# Altium schematic sheet Sensors.SchDoc(Sensors)
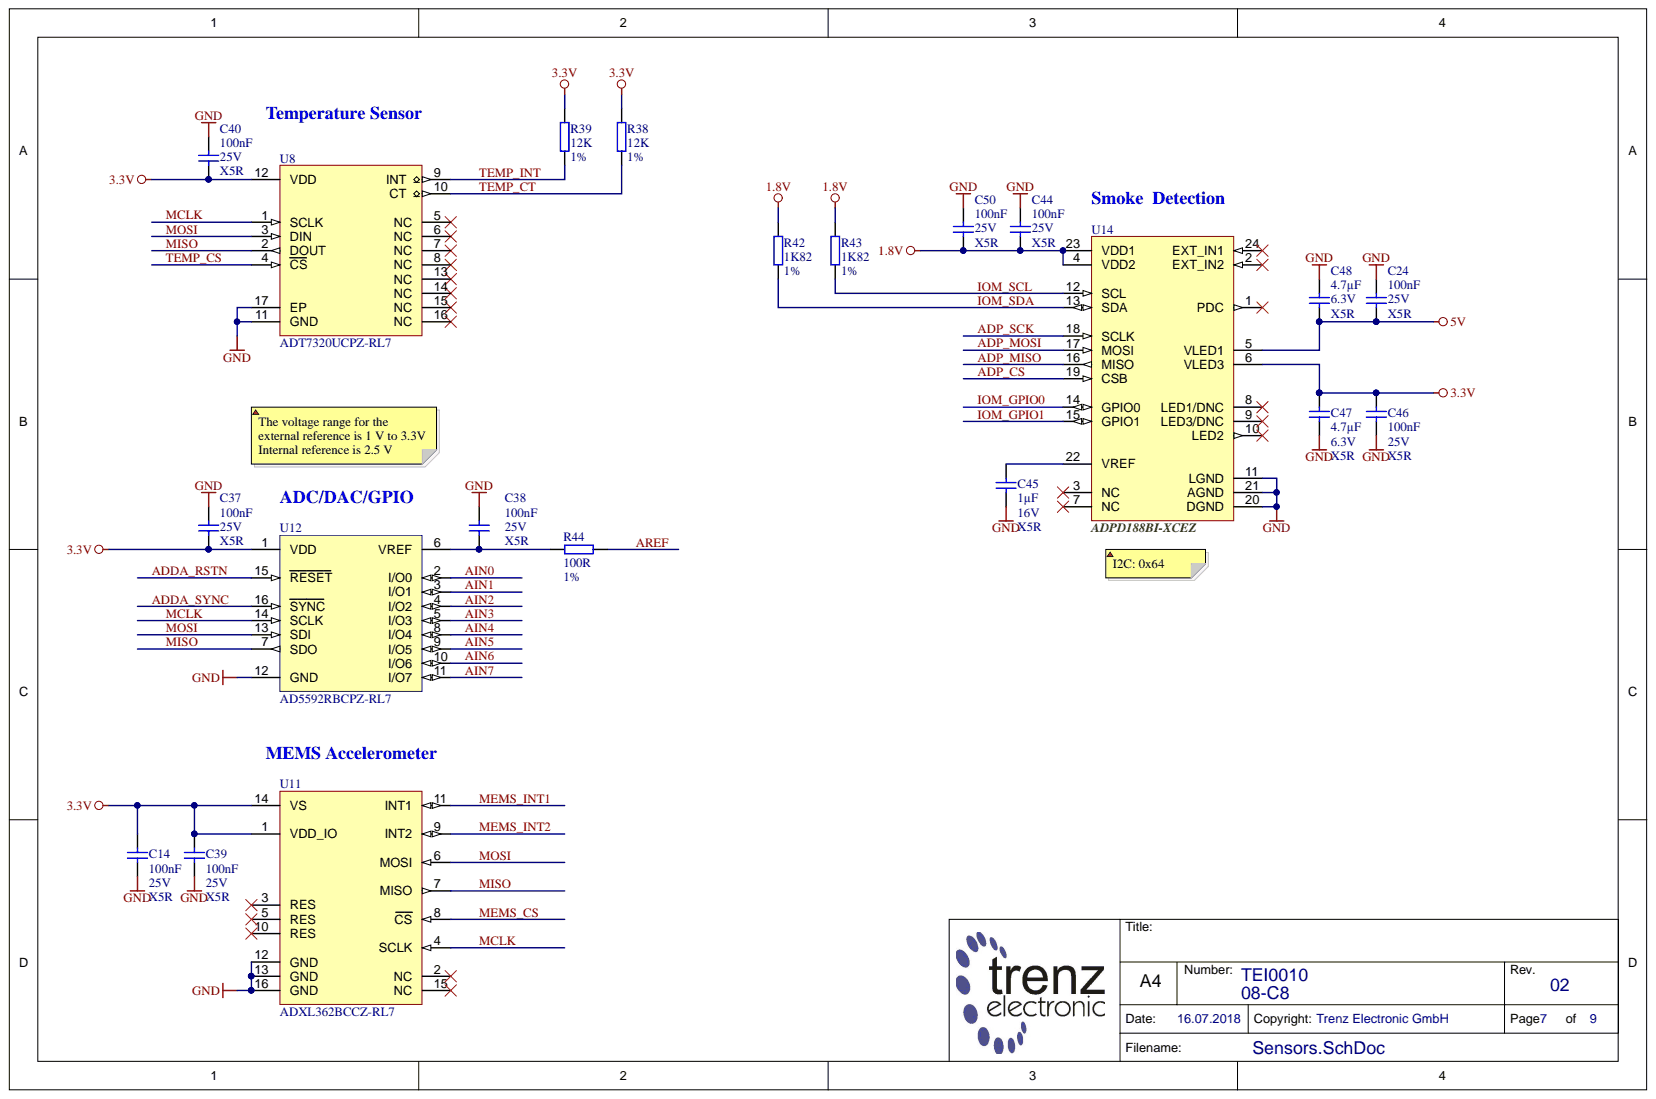
[diagram p0: Sensors.SchDoc]

## Parts
C14  100nF  Technology: X5R  Voltage: 25V  [CAPC1005X55N]
C24  100nF  Technology: X5R  Voltage: 25V  [CAPC1005X55N]
C37  100nF  Technology: X5R  Voltage: 25V  [CAPC1005X55N]
C38  100nF  Technology: X5R  Voltage: 25V  [CAPC1005X55N]
C39  100nF  Technology: X5R  Voltage: 25V  [CAPC1005X55N]
C40  100nF  Technology: X5R  Voltage: 25V  [CAPC1005X55N]
C44  100nF  Technology: X5R  Voltage: 25V  [CAPC1005X55N]
C45  1µF  Technology: X5R  Voltage: 16V  [CAPC1005X55N]
C46  100nF  Technology: X5R  Voltage: 25V  [CAPC1005X55N]
C47  4.7µF  Technology: X5R  Voltage: 6.3V  [CAPC1005X60N]
C48  4.7µF  Technology: X5R  Voltage: 6.3V  [CAPC1005X60N]
C50  100nF  Technology: X5R  Voltage: 25V  [CAPC1005X55N]
R38  12K  Tolerance: 1%  [RESC1005X40N]
R39  12K  Tolerance: 1%  [RESC1005X40N]
R42  1K82  Tolerance: 1%  [RESC1005X40N]
R43  1K82  Tolerance: 1%  [RESC1005X40N]
R44  100R  Tolerance: 1%  [RESC1005X40N]
U8  ADT7320UCPZ-RL7  [QFN65P400X400X80-17N]
U11  ADXL362BCCZ-RL7  [PQFN50P300X322X114-16N]
U12  AD5592RBCPZ-RL7  [QFN50P300X300X80-16N]
U14  ADPD188BI-XCEZ  [PQFN50P500X380X100-24N]

## Nets
1.8V: C44.1 C50.1 R42.2 R43.2 U14.4 U14.23
3.3V: C14.1 C37.1 C39.1 C40.1 C46.2 C47.1 R38.2 R39.2 U8.12(VDD) U11.1(VDD_IO) U11.14(VS) U12.1(VDD) U14.6
5V: C24.1 C48.1 U14.5
GND: C14.2 C24.2 C37.2 C38.2 C39.2 C40.2 C44.2 C45.2 C46.1 C47.2 C48.2 C50.2 U8.11(GND) U8.17(EP) U11.12(GND) U11.13(GND) U11.16(GND) U12.12(GND) U14.11 U14.20 U14.21
ADDA_RSTN: U12.15(RESET)
ADDA_SYNC: U12.16(SYNC)
ADP_CS: U14.19
ADP_MISO: U14.16
ADP_MOSI: U14.17
ADP_SCK: U14.18
AIN0: U12.2(I/O0)
AIN1: U12.3(I/O1)
AIN2: U12.4(I/O2)
AIN3: U12.5(I/O3)
AIN4: U12.8(I/O4)
AIN5: U12.9(I/O5)
AIN6: U12.10(I/O6)
AIN7: U12.11(I/O7)
AREF: R44.2
IOM_GPIO0: U14.14
IOM_GPIO1: U14.15
IOM_SCL: R43.1 U14.12
IOM_SDA: R42.1 U14.13
MCLK: U8.1(SCLK) U11.4(SCLK) U12.14(SCLK)
MEMS_CS: U11.8(CS)
MEMS_INT1: U11.11(INT1)
MEMS_INT2: U11.9(INT2)
MISO: U8.2(DOUT) U11.7(MISO) U12.7(SDO)
MOSI: U8.3(DIN) U11.6(MOSI) U12.13(SDI)
TEMP_CS: U8.4(CS)
TEMP_CT: R38.1 U8.10(CT)
TEMP_INT: R39.1 U8.9(INT)
NetC38_1: C38.1 R44.1 U12.6(VREF)
NetC45_1: C45.1 U14.22

Not connected: U8 5(NC) 6(NC) 7(NC) 8(NC) 13(NC) 14(NC) 15(NC) 16(NC); U11 2(NC) 3(RES) 5(RES) 10(RES) 15(NC); U14 1 2 3 7 8 9 10 24

## Library data of the parts
C14 C24 C37-C40 C44 C46 C50 = 22472: KON 100nF 25V X5R ±10% SMD BF 1005 (0402); Database Table Name: C_1005_0402; Mixed: SMT; Preferred: Yes; Temperature-Range: -55/+85; Value: 100n; AN: 22472; Case: 1005 (0402); Component Kind: Standard; Library Name: TE.DbLib
C45 = 26579: KON 1µF 16V X5R 10% SMD BF 1005 (0402); Database Table Name: C_1005_0402; Mixed: SMT; Temperature-Range: -55/+85; Value: 1u; AN: 26579; Case: 1005 (0402); Component Kind: Standard; Library Name: TE.DbLib; Tolerance: 10%
C47 C48 = 24719: KON 4.7µF 6.3V X5R 20% SMD BF 1005 (0402); Database Table Name: C_1005_0402; Mixed: SMT; Preferred: Yes; Temperature-Range: -55/+85; Value: 4.7u; AN: 24719; Case: 1005 (0402); Component Kind: Standard; Library Name: TE.DbLib; Tolerance: 20%
R38 R39 = 22598: WID SMD 12K 1% 0,063W  BF 1005 (0402); Database Table Name: R_1005_0402; Mixed: SMT; Preferred: Yes; AN: 22598; Case: 1005 (0402); Component Kind: Standard; Library Name: TE.DbLib; Technology: TK100; Value: 12K
R42 R43 = 26021: WID SMD 1K82 1% 0,063W; Database Table Name: R_1005_0402; Mixed: SMT; Preferred: Yes; Value: 1.82K; AN: 26021; Case: 1005 (0402); Component Kind: Standard; Library Name: TE.DbLib; Technology: TK100
R44 = 22507: WID SMD 100R 1% 0,063W  BF 1005 (0402); Database Table Name: R_1005_0402; H-ART: RC0402FR-13100RL; Mixed: SMT; Preferred: Yes; Value: 100; AN: 22507; Case: 1005 (0402); Component Kind: Standard; Library Name: TE.DbLib; Technology: TK100
U8 = 28910: Database Table Name: SENSOR; Mixed: SMT; AN: 28910; Case: QFN16 (LFCSP16); Component Kind: Standard; Description: ADT7320UCPZ-RL7; H-ART: ADT7320UCPZ-RL7; Library Name: TE.DbLib; HelpURL: b:\AD\adlib\datasheet\ADT7320\
U11 = 28912: Database Table Name: SENSOR; Mixed: SMT; AN: 28912; Case: LGA16; Component Kind: Standard; Description: ADXL362BCCZ-RL7; H-ART: ADXL362BCCZ-RL7; Library Name: TE.DbLib; HelpURL: b:\AD\adlib\datasheet\ADXL362\
U12 = 28911: Database Table Name: ADC; Mixed: SMT; AN: 28911; Case: QFN16; Component Kind: Standard; Description: AD5592RBCPZ-RL7; H-ART: AD5592RBCPZ-RL7; Library Name: TE.DbLib; HelpURL: b:\AD\adlib\datasheet\AD5592R\
U14 = 28971: Database Table Name: SENSOR; Mixed: SMT; AN: 28971; Case: LGA24; Component Kind: Standard; Description: ADPD188BI-XCEZ; H-ART: ADPD188BI-XCEZ; Library Name: TE.DbLib; HelpURL: b:\AD\adlib\datasheet\ADPD188BI\
